# Altium schematic sheet Signal_Integrity_4L.SchDoc
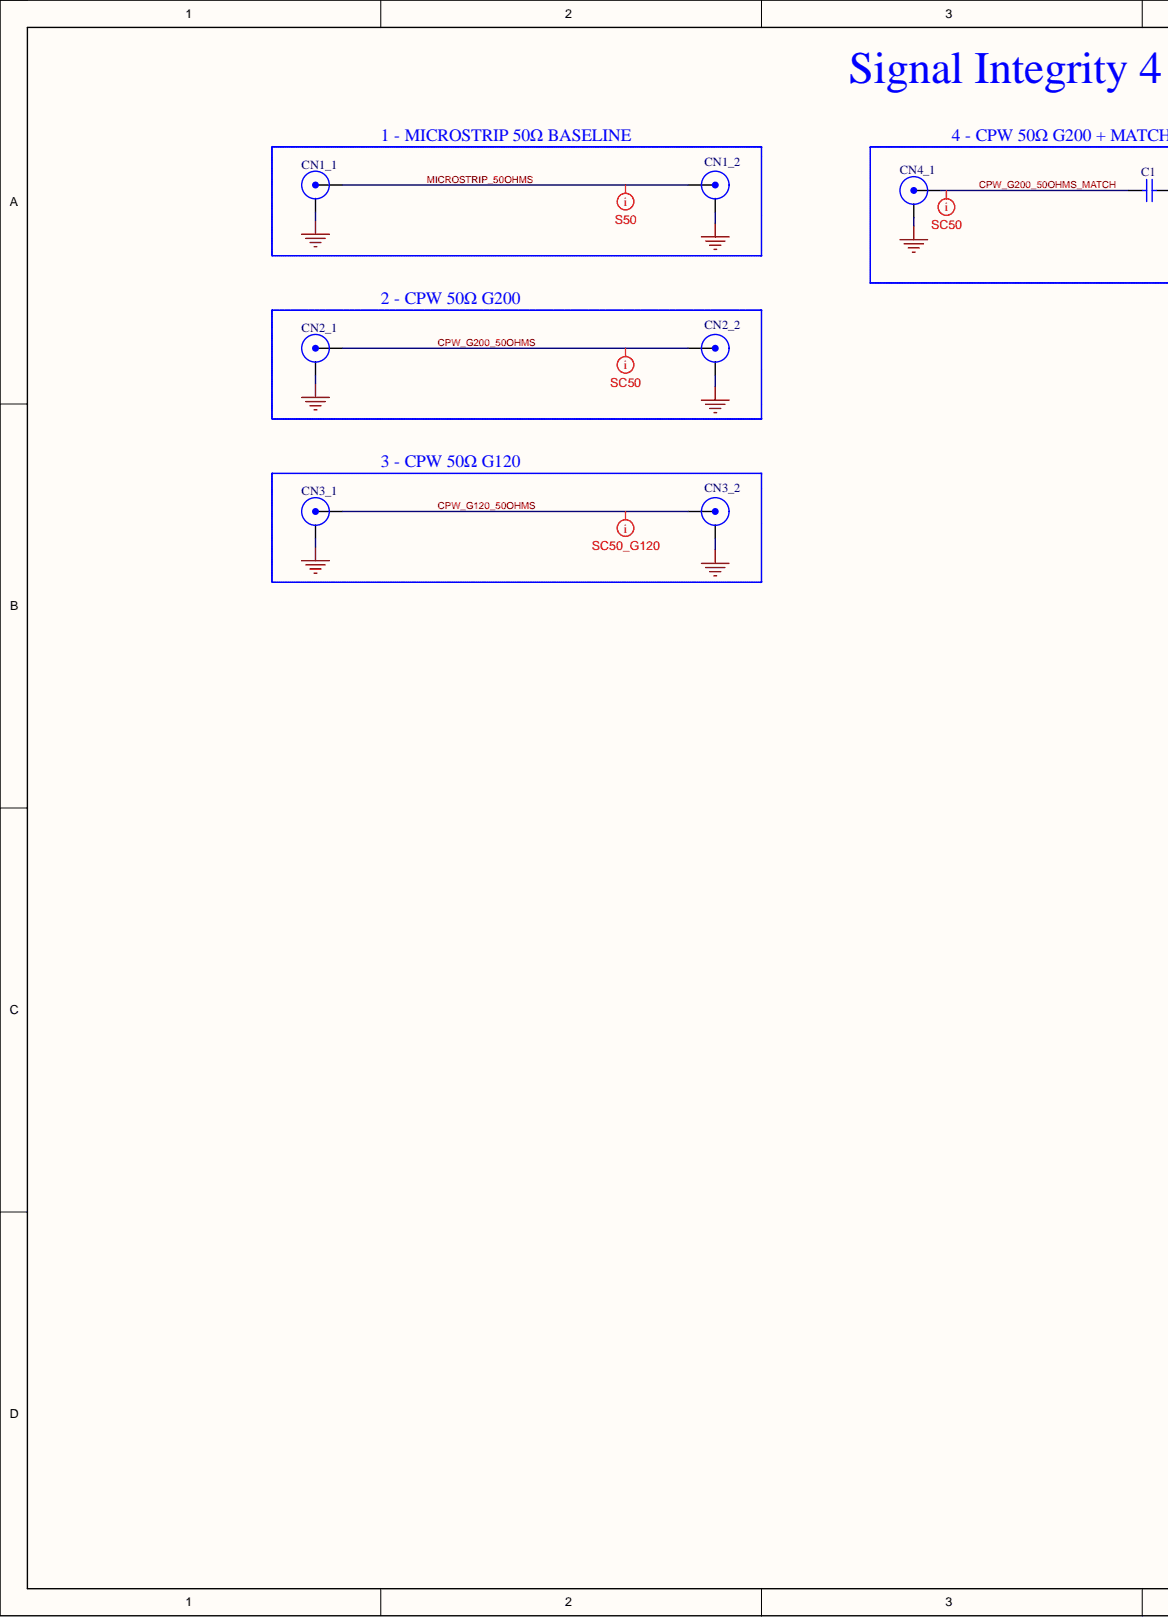
[diagram p0: Signal_Integrity_4L.SchDoc, left]
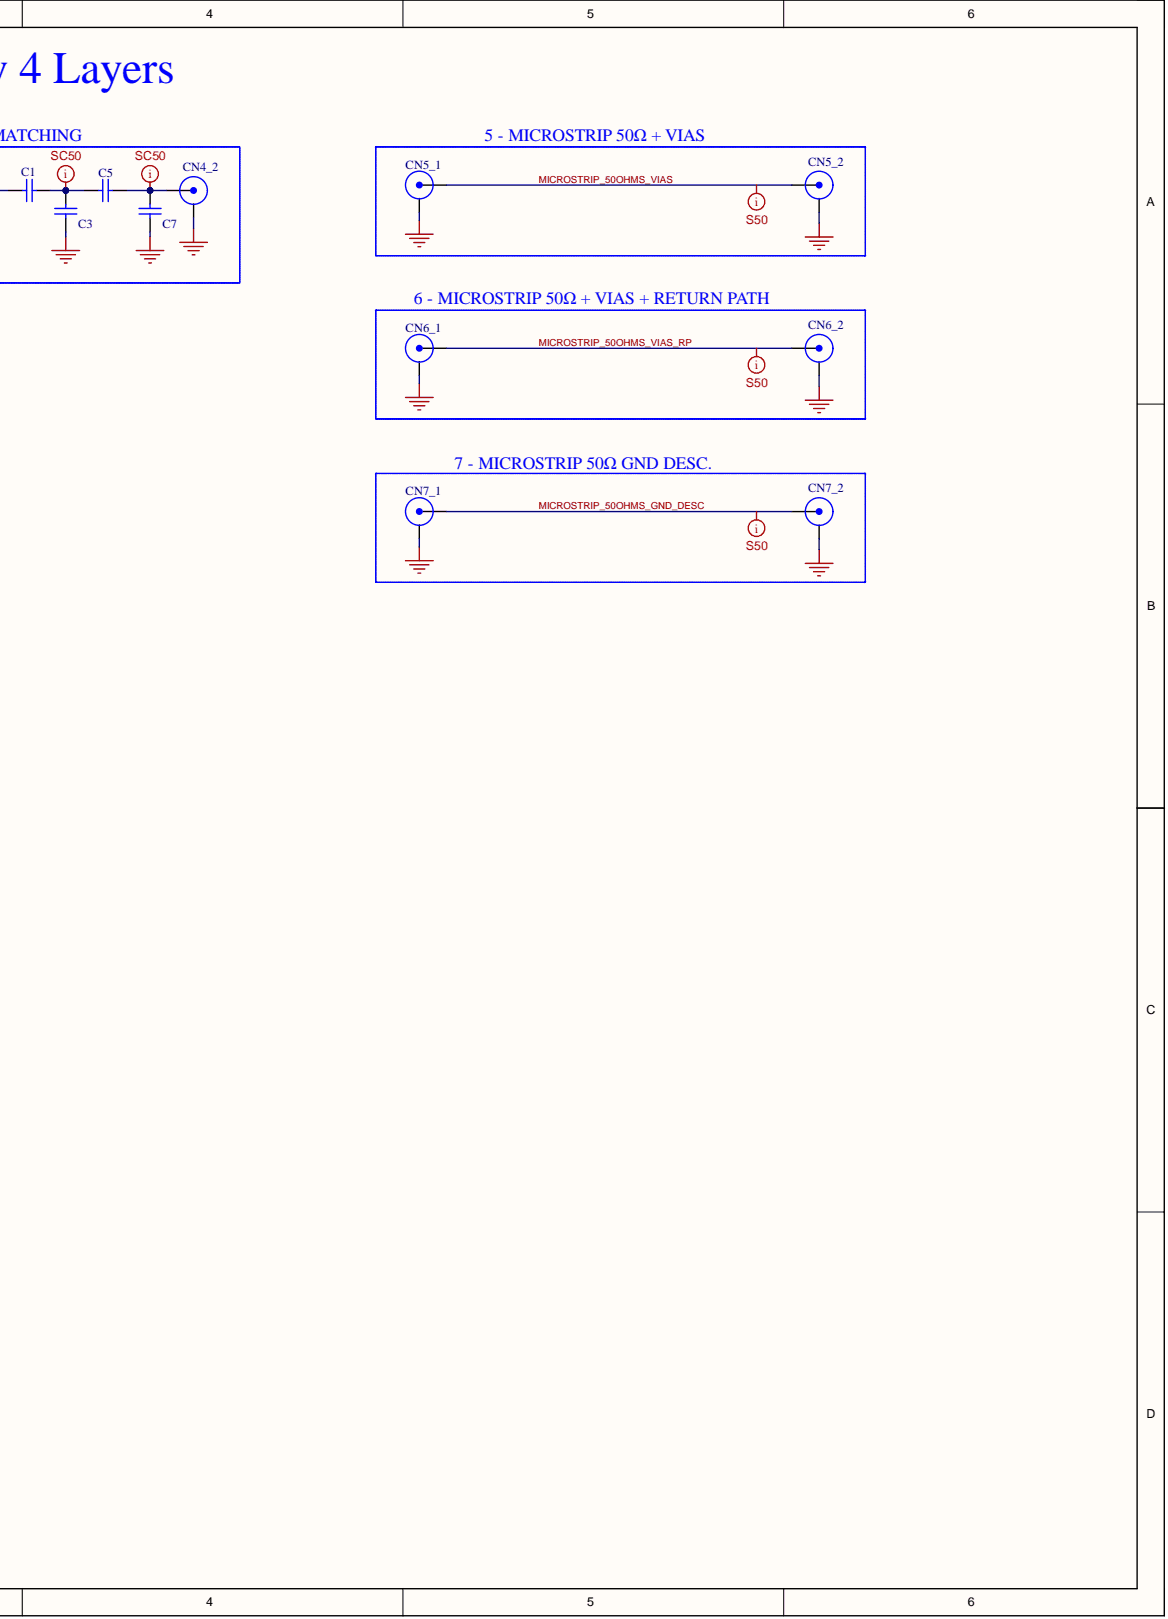
[diagram p1: Signal_Integrity_4L.SchDoc, right]

## Parts
C1  CAP SMD 0402  [0402]  (value not drawn)
C3  CAP SMD 0402  [0402]  (value not drawn)
C5  CAP SMD 0402  [0402]  (value not drawn)
C7  CAP SMD 0402  [0402]  (value not drawn)
CN1_1  SMA-KE EDGE  [SMA-KE EDGE]  (value not drawn)
CN1_2  SMA-KE EDGE  [SMA-KE EDGE]  (value not drawn)
CN2_1  SMA-KE EDGE  [SMA-KE EDGE]  (value not drawn)
CN2_2  SMA-KE EDGE  [SMA-KE EDGE]  (value not drawn)
CN3_1  SMA-KE EDGE  [SMA-KE EDGE]  (value not drawn)
CN3_2  SMA-KE EDGE  [SMA-KE EDGE]  (value not drawn)
CN4_1  SMA-KE EDGE  [SMA-KE EDGE]  (value not drawn)
CN4_2  SMA-KE EDGE  [SMA-KE EDGE]  (value not drawn)
CN5_1  SMA-KE EDGE  [SMA-KE EDGE]  (value not drawn)
CN5_2  SMA-KE EDGE  [SMA-KE EDGE]  (value not drawn)
CN6_1  SMA-KE EDGE  [SMA-KE EDGE]  (value not drawn)
CN6_2  SMA-KE EDGE  [SMA-KE EDGE]  (value not drawn)
CN7_1  SMA-KE EDGE  [SMA-KE EDGE]  (value not drawn)
CN7_2  SMA-KE EDGE  [SMA-KE EDGE]  (value not drawn)

## Nets
GND1: CN1_1.2 CN1_2.2
GND2: CN2_1.2 CN2_2.2
GND3: CN3_1.2 CN3_2.2
GND4: C3.1 C7.1 CN4_1.2 CN4_2.2
GND5: CN5_1.2 CN5_2.2
GND6: CN6_1.2 CN6_2.2
GND7: CN7_1.2 CN7_2.2
CPW_G120_50OHMS: CN3_1.1 CN3_2.1
CPW_G200_50OHMS: CN2_1.1 CN2_2.1
CPW_G200_50OHMS_MATCH: C1.1 CN4_1.1
MICROSTRIP_50OHMS: CN1_1.1 CN1_2.1
MICROSTRIP_50OHMS_GND_DESC: CN7_1.1 CN7_2.1
MICROSTRIP_50OHMS_VIAS: CN5_1.1 CN5_2.1
MICROSTRIP_50OHMS_VIAS_RP: CN6_1.1 CN6_2.1
NetC1_2: C1.2 C3.2 C5.1
NetC5_2: C5.2 C7.2 CN4_2.1

## Library data of the parts
C1 C3 C5 C7 = CAP SMD 0402: 0402; Component Kind: Standard; Library Name: Impedance.IntLib
CN1_1 CN1_2 CN2_1 CN2_2 CN3_1 CN3_2 CN4_1 CN4_2 CN5_1 CN5_2 CN6_1 CN6_2 CN7_1 CN7_2 = SMA-KE EDGE: SMA Connector Receptacle, Female Socket 50Ohm Board Edge, End Launch Solder; Manufacturer_Name: TE Connectivity; Manufacturer_Part_Number: CONSMA020.062-G; Geometry.Height: 7.92mm; Component Kind: Standard; Datasheet Link: https://componentsearchengine.com/Datasheets/1/CONSMA020.062-G.pdf; Mouser Part Number: 712-CONSMA020.062-G; Mouser Price/Stock: https://www.mouser.co.uk/ProductDetail/Linx-Technologies/CONSMA020.062-G?qs=xZ%2FP%252Ba9zWqa7zEs9%2FuMaNQ%3D%3D; Library Name: Impedance.IntLib
